# Altium schematic sheet ExternalDisplay.SchDoc(ExternalDisplay)
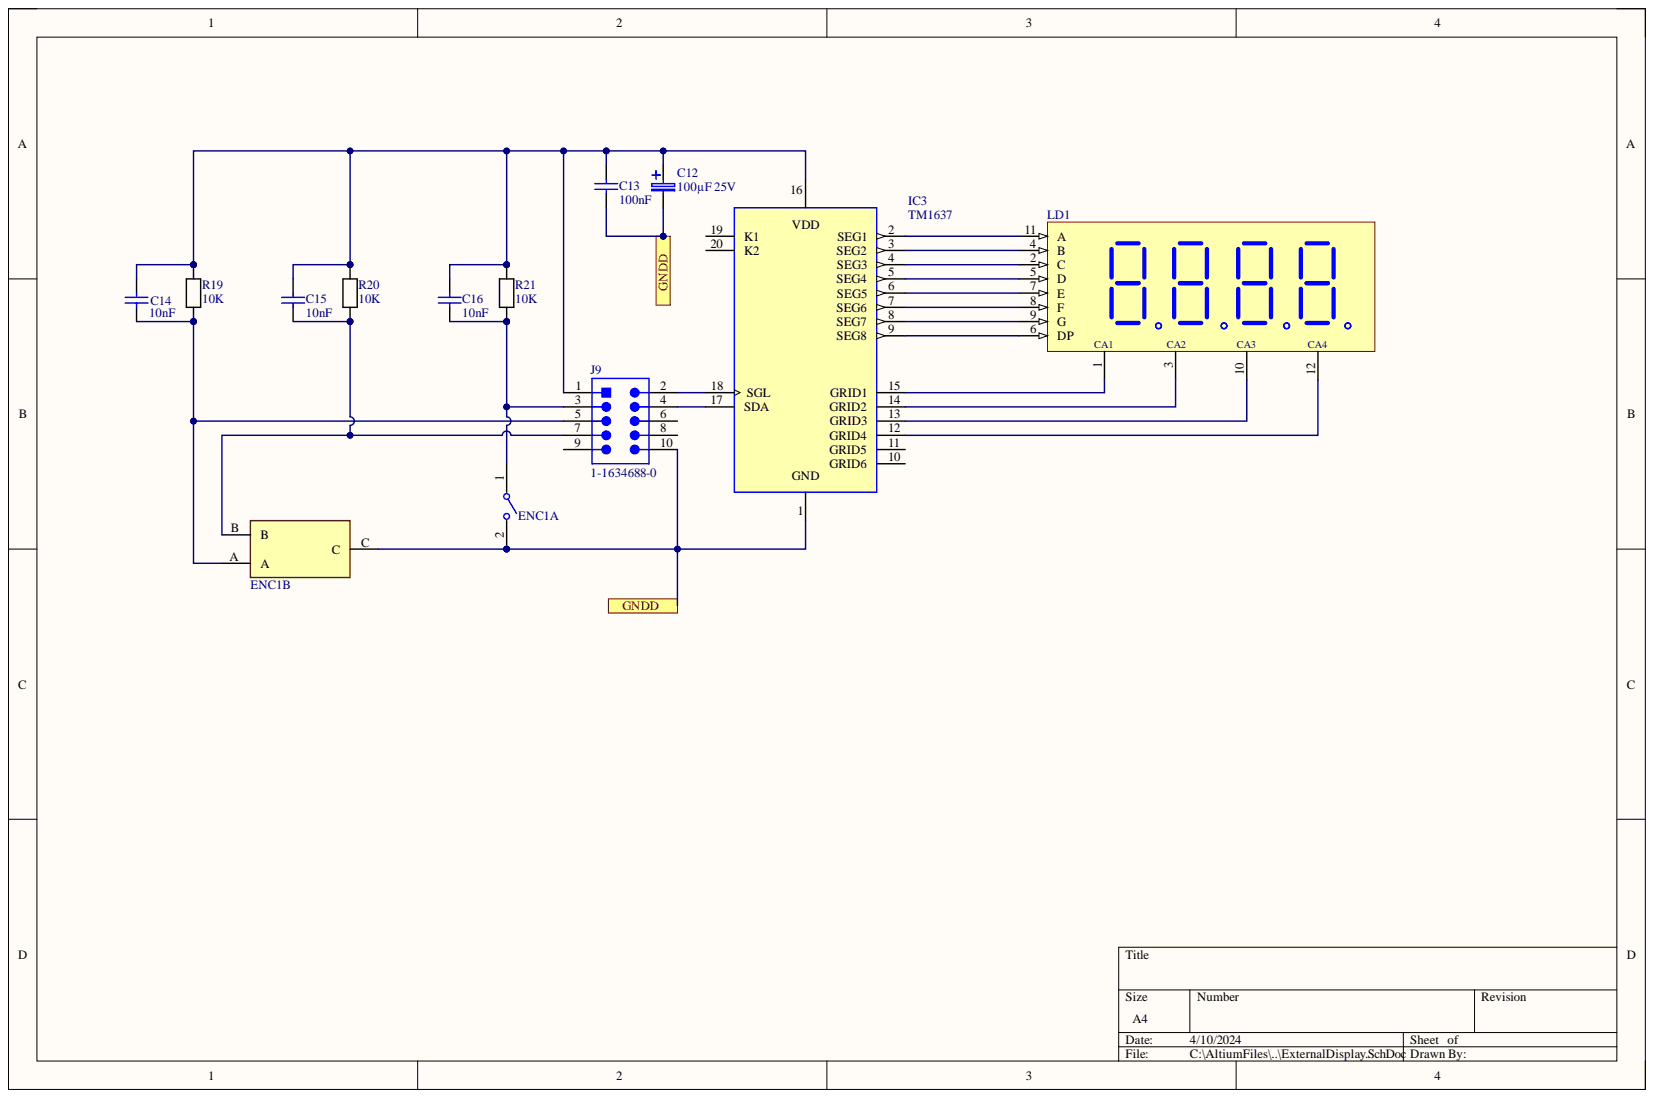
[diagram p0: ExternalDisplay.SchDoc]

## Parts
C12  100µF 25V  [FP-T495X107K025ATE150-MFG]
C13  100nF  [CAPC2013X88X50LL20T25]
C14  10nF  [CAPC2013X88X50LL20T25]
C15  10nF  [CAPC2013X88X50LL20T25]
C16  10nF  [CAPC2013X88X50LL20T25]
ENC1  PEC11R-4220F-S0012  [PEC11R_4220F_S0012]  (value not drawn)  (parts A,B)
IC3  TM1637  [N-20]
J9  1-1634688-0  [TECO-1-1634688-0-10_V]
LD1  KW4-801AVB  [KW4-801AVB/KW4-801CVB]  (value not drawn)
R19  10K  [RESC2013X50X30LL20T20]
R20  10K  [RESC2013X50X30LL20T20]
R21  10K  [RESC2013X50X30LL20T20]

## Ports
GNDD

## Nets
GNDD: C12.2 C13.1 ENC1.2 ENC1.C IC3.1 J9.10 ; port GNDD
NetC12_1: C12.1 C13.2 C14.2 C15.2 C16.2 IC3.16 J9.1 R19.2 R20.2 R21.2
NetC14_1: C14.1 ENC1.A J9.5 R19.1
NetC15_1: C15.1 ENC1.B J9.7 R20.1
NetC16_1: C16.1 ENC1.1 J9.3 R21.1
NetIC3_2: IC3.2(SEG1) LD1.11(A)
NetIC3_3: IC3.3(SEG2) LD1.4(B)
NetIC3_4: IC3.4(SEG3) LD1.2(C)
NetIC3_5: IC3.5(SEG4) LD1.5(D)
NetIC3_6: IC3.6(SEG5) LD1.7(E)
NetIC3_7: IC3.7(SEG6) LD1.8(F)
NetIC3_8: IC3.8(SEG7) LD1.9(G)
NetIC3_9: IC3.9(SEG8) LD1.6(DP)
NetIC3_12: IC3.12(GRID4) LD1.12
NetIC3_13: IC3.13(GRID3) LD1.10
NetIC3_14: IC3.14(GRID2) LD1.3
NetIC3_15: IC3.15(GRID1) LD1.1
NetIC3_17: IC3.17(SDA) J9.4
NetIC3_18: IC3.18(SGL) J9.2

Not connected: IC3 10(GRID6) 11(GRID5) 19(K1) 20(K2); J9 6 8 9

## Library data of the parts
C12 = capacitor SMD 100µF 25V: CAP TANT 100UF 10% 25V 2917CMP-03017-000022-1495X107K025ATE150; ESR (Equivalent Series Resistance): 150mR; Life (Hours): 2000Hour; Polarity: Polar; Capacitance: 100uF; Case Code (Imperial): 2917; Case Code (Metric): 7343; Case/Package: Molded; Component Kind: Standard; Depth: 4.3mm; Dissipation Factor: 10%; Features: General Purpose; Height: 4mm; Height - Seated (Max): 0.169inch; Lead Free: Lead Free; Length: 7.3mm; Library Name: SolderStation.SchLib; Max Operating Temperature: 125°C; Min Operating Temperature: -55°C; Mount: Surface Mount; Package Quantity: 500; Packaging: Cut Tape; Radiation Hardening: No; REACH SVHC: No SVHC; RoHS Compliant: Yes; Tolerance: 10%; Voltage Rating: 25V; Voltage Rating (DC): 25V; Width: 0.169inch
C13-C16 = condensator 0805: Manufacturer: Kemet; Part Number: C0402C104K4RACTU; Component Kind: Standard; Library Name: SolderStation.SchLib
ENC1 = PEC11R-4220F-S0012: ROTARY ENCODER MECHANICAL 12PPR; Built in Switch: Yes; Hole Diameter: 7mm; Number of Channels: 2; Output Type: Quadrature; Pulses per Revolution: 12; Component Kind: Standard; Detent: Yes; Encoder Type: Mechanical; Height: 13.4mm; Length: 26.5mm; Library Name: SolderStation.SchLib; Max Operating Temperature: 70°C; Min Operating Temperature: -30°C; Mount: Through Hole; Number of Pins: 5; Orientation: Vertical; Package Quantity: 150; Packaging: Bulk; Radiation Hardening: No; REACH SVHC: No SVHC; RoHS Compliant: Yes; Series: PEC11R; Termination: PC Pin; Type: Mechanical; Width: 12.5mm
IC3 = TM1637: LED Drive Control Special Circuit; MF: Titan Micro Electronics; Availability: Not in stock; Check_prices: https://www.snapeda.com/parts/TM1637/Titan+Micro+Electronics/view-part/?ref=eda; Component Kind: Standard; Library Name: SolderStation.SchLib; MP: TM1637; Package: Package; Price: None; SnapEDA_Link: https://www.snapeda.com/parts/TM1637/Titan+Micro+Electronics/view-part/?ref=snap
J9 = pin header 2X5 10pins: CMP-2000-05854-1 Male Header, Pitch 2.54 mm, 2 x 5 Postion, Height 9.15 mm, Tail Length 3.2 mm, -40 to 105 degC, RoHS, Bulk; Manufacturer: TE Connectivity; Contact Termination Type: ThroughHole; No. of Contacts: 10Contacts; No. of Positions: 10; No. of Rows: 2; Package Version: Rev. C2, 02/2011; Pitch: 2.54mm; SVHC: ToBeAdvised; Component Kind: Standard; Contact Material: Brass; Contact Plating: GoldPlatedContacts; Datasheet URL: https://datasheet.ciiva.com/6831/eng-cd-1634688-c2-6831201.pdf; Datasheet Version: Rev. C2, 02/2011; Gender: Header; Library Name: SolderStation.SchLib; Manufacturer URL: http://www.te.com/; Mounting Technology: ThroughHole; Orientation: Straight; Package Description: 10-Pin Connector, Body 20.35 x 8.7 mm, Pitch 2.54 mm; Package Reference: 1-1634688-0; Package URL: https://datasheet.ciiva.com/6831/eng-cd-1634688-c2-6831201.pdf; PartNumber: 1-1634688-0; Pitch Spacing: 2.54mm; Product Range: -
LD1 = KW4-801AVB: 4-Digit LED Display TME; Component Kind: Standard; Library Name: SolderStation.SchLib
R19-R21 = resistor 0805: Manufacturer: Vishay Dale; Part Number: CRCW08050000Z0EA; Component Kind: Standard; Library Name: SolderStation.SchLib
